# Altium schematic sheet I_PRV_UWS_B2_1_0a_main.SchDoc
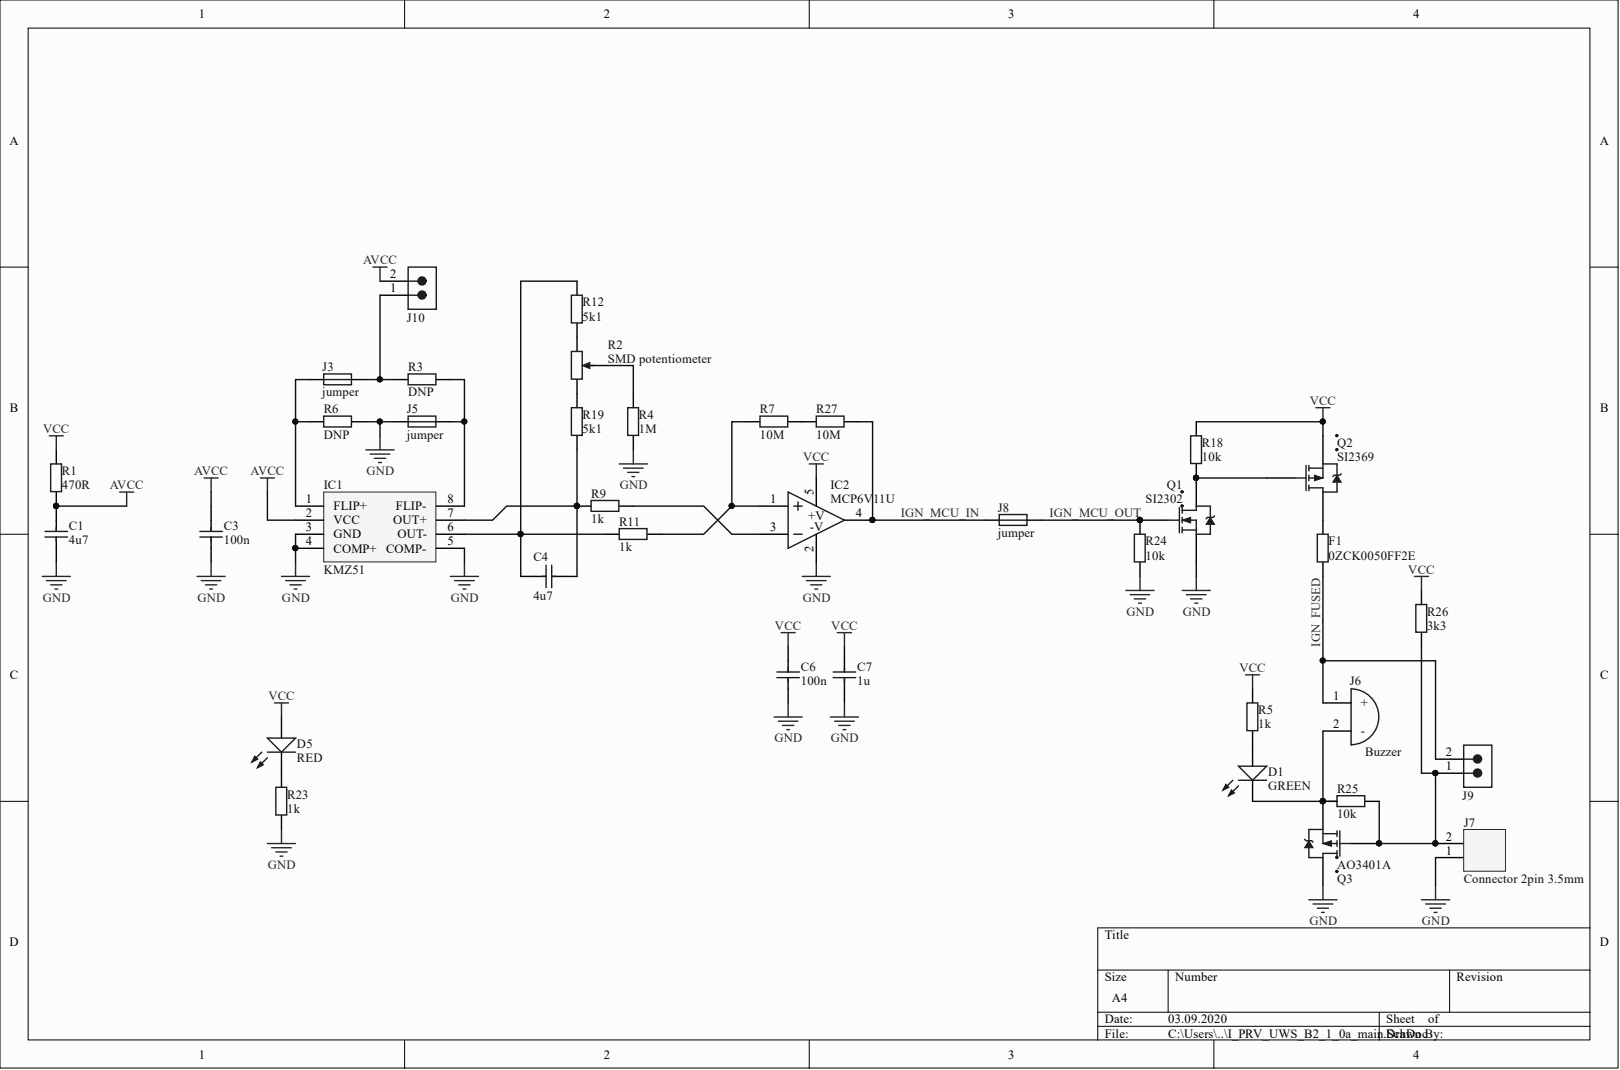
[diagram p0: I_PRV_UWS_B2_1_0a_main.SchDoc]

## Parts
C1  value 4u7  [SMD0603_CAP]  (hidden comment: Capacitor)
C3  value 100n  [SMD0402_CAP]  (hidden comment: Capacitor)
C4  value 4u7  [SMD0402_CAP]  (hidden comment: Capacitor)
C6  value 100n  [SMD0402_CAP]  (hidden comment: Capacitor)
C7  value 1u  [SMD0402_CAP]  (hidden comment: Capacitor)
D1  value GREEN  [SMD0603_LED]  (hidden comment: LED)
D5  value RED  [SMD0603_LED]  (hidden comment: LED)
F1  value 0ZCK0050FF2E  [SMD0805_RES]  (hidden comment: Resistor)
IC1  KMZ51  [KMZ51_SO8]
IC2  MCP6V11U  [SOT23-5]
J3  jumper  [0402_jumper]
J5  jumper  [0402_jumper]
J6  Buzzer  [BUZZER 9.5x5]
J7  Connector 2pin 3.5mm  [Connector 2pin 3.5mm]
J8  jumper  [0402_jumper]
J9  Pinhead 2pin 2.54mm  [Pinhead 2pi 2.54mm]  (value not drawn)
J10  Pinhead 2pin 2.54mm  [Pinhead 2pi 2.54mm]  (value not drawn)
Q1  SI2302  [SOT_23]
Q2  SI2369  [SOT_23]
Q3  AO3401A  [SOT_23]
R1  value 470R  [SMD0402_RES]  (hidden comment: Resistor)
R2  SMD potentiometer  [Pot 1804]
R3  value DNP  [SMD0402_RES]  (hidden comment: Resistor)
R4  value 1M  [SMD0402_RES]  (hidden comment: Resistor)
R5  value 1k  [SMD0402_RES]  (hidden comment: Resistor)
R6  value DNP  [SMD0402_RES]  (hidden comment: Resistor)
R7  value 10M  [SMD0402_RES]  (hidden comment: Resistor)
R9  value 1k  [SMD0402_RES]  (hidden comment: Resistor)
R11  value 1k  [SMD0402_RES]  (hidden comment: Resistor)
R12  value 5k1  [SMD0402_RES]  (hidden comment: Resistor)
R18  value 10k  [SMD0402_RES]  (hidden comment: Resistor)
R19  value 5k1  [SMD0402_RES]  (hidden comment: Resistor)
R23  value 1k  [SMD0402_RES]  (hidden comment: Resistor)
R24  value 10k  [SMD0402_RES]  (hidden comment: Resistor)
R25  value 10k  [SMD0402_RES]  (hidden comment: Resistor)
R26  value 3k3  [SMD0402_RES]  (hidden comment: Resistor)
R27  value 10M  [SMD0402_RES]  (hidden comment: Resistor)

## Nets
AVCC: C1.2 C3.2 IC1.2(VCC) J10.2 R1.2
GND: C1.1 C3.1 C6.1 C7.1 IC1.3(GND) IC1.4(COMP+) IC1.5(COMP-) IC2.2(-V) J5.2 J7.1 Q1.2 Q3.3 R4.2 R6.2 R23.1 R24.1
VCC: C6.2 C7.2 D5.2 IC2.5(+V) Q2.2 R1.1 R5.2 R18.2 R26.2
IGN_FUSED: F1.1 J6.1 J9.2
IGN_MCU_IN: IC2.4 J8.1 R27.2
IGN_MCU_OUT: J8.2 Q1.1 R24.2
NetC4_1: C4.1 IC1.7(OUT+) R9.1 R19.2
NetC4_2: C4.2 IC1.6(OUT-) R11.1 R12.1
NetD1_1: D1.1 J6.2 Q3.2 R25.2
NetD1_2: D1.2 R5.1
NetD5_1: D5.1 R23.2
NetF1_2: F1.2 Q2.3
NetIC1_1: IC1.1(FLIP+) J3.2 R6.1
NetIC1_8: IC1.8(FLIP-) J5.1 R3.2
NetIC2_1: IC2.1 R7.1 R11.2
NetIC2_3: IC2.3 R9.2
NetJ3_1: J3.1 J10.1 R3.1
NetJ7_2: J7.2 J9.1 Q3.1 R25.1 R26.1
NetQ1_3: Q1.3 Q2.1 R18.1
NetR2_1: R2.1 R19.1
NetR2_2: R2.2 R12.2
NetR2_3: R2.3 R4.1
NetR7_2: R7.2 R27.1

## Library data of the parts
IC2 = LMV321: LMV321 Opamp; Component Kind: Standard; LCSC Part #: C144173; Library Name: BM_UWS.SchLib
J3 J5 J8 = 0402 jumper: Component Kind: Standard; Library Name: BM_UWS.SchLib
Q1 = IRLML6246: HEXFET Power N-Channel MOSFET; Component Kind: Standard; LCSC Part #: C181087; Library Name: TCH_SCH_LIB.SchLib
Q2 Q3 = IRLML6402: HEXFET Power P-Channel MOSFET; Component Kind: Standard; Library Name: TCH_SCH_LIB.SchLib
  Q2: LCSC Part #: C141546
  Q3: LCSC Part #: C15127
R2 = Potentiometer 1804 (3314): Component Kind: Standard; Library Name: BM_UWS.SchLib
C1 C3 C4 C6 C7 = Capacitor: Component Kind: Standard; Description: Capacitor; Library Name: KD_SCH_LIB.SchLib
  C1: LCSC Part #: C19666
  C3 C6: LCSC Part #: C1525
  C4: LCSC Part #: C23733
  C7: LCSC Part #: C52923
D1 D5 = LED: Component Kind: Standard; Description: LED; Library Name: KD_SCH_LIB.SchLib
  D1: LCSC Part #: C72043
  D5: LCSC Part #: C2286
F1 R1 R3-R7 R9 R11 R12 R18 R19 R23-R27 = Resistor: Component Kind: Standard; Description: Resistor; Library Name: KD_SCH_LIB.SchLib
  F1: LCSC Part #: C261942
  R1: LCSC Part #: C25117
  R4: LCSC Part #: C26083
  R5 R9 R11 R23: LCSC Part #: C11702
  R7 R27: LCSC Part #: C26082
  R12 R19: LCSC Part #: C25905
  R18 R24 R25: LCSC Part #: C25744
  R26: LCSC Part #: C25890
IC1 = KMZ51: Component Kind: Standard; Library Name: BM_UWS.SchLib
J6 = Buzzer: Component Kind: Standard; Library Name: BM_UWS.SchLib
J7 = Connector 2pin 3.5mm: Component Kind: Standard; Library Name: BM_UWS.SchLib
J9 J10 = Pinhead 2pin 2.54mm: Component Kind: Standard; Library Name: BM_UWS.SchLib
